# Altium schematic sheet pt_ctrl.SchDoc
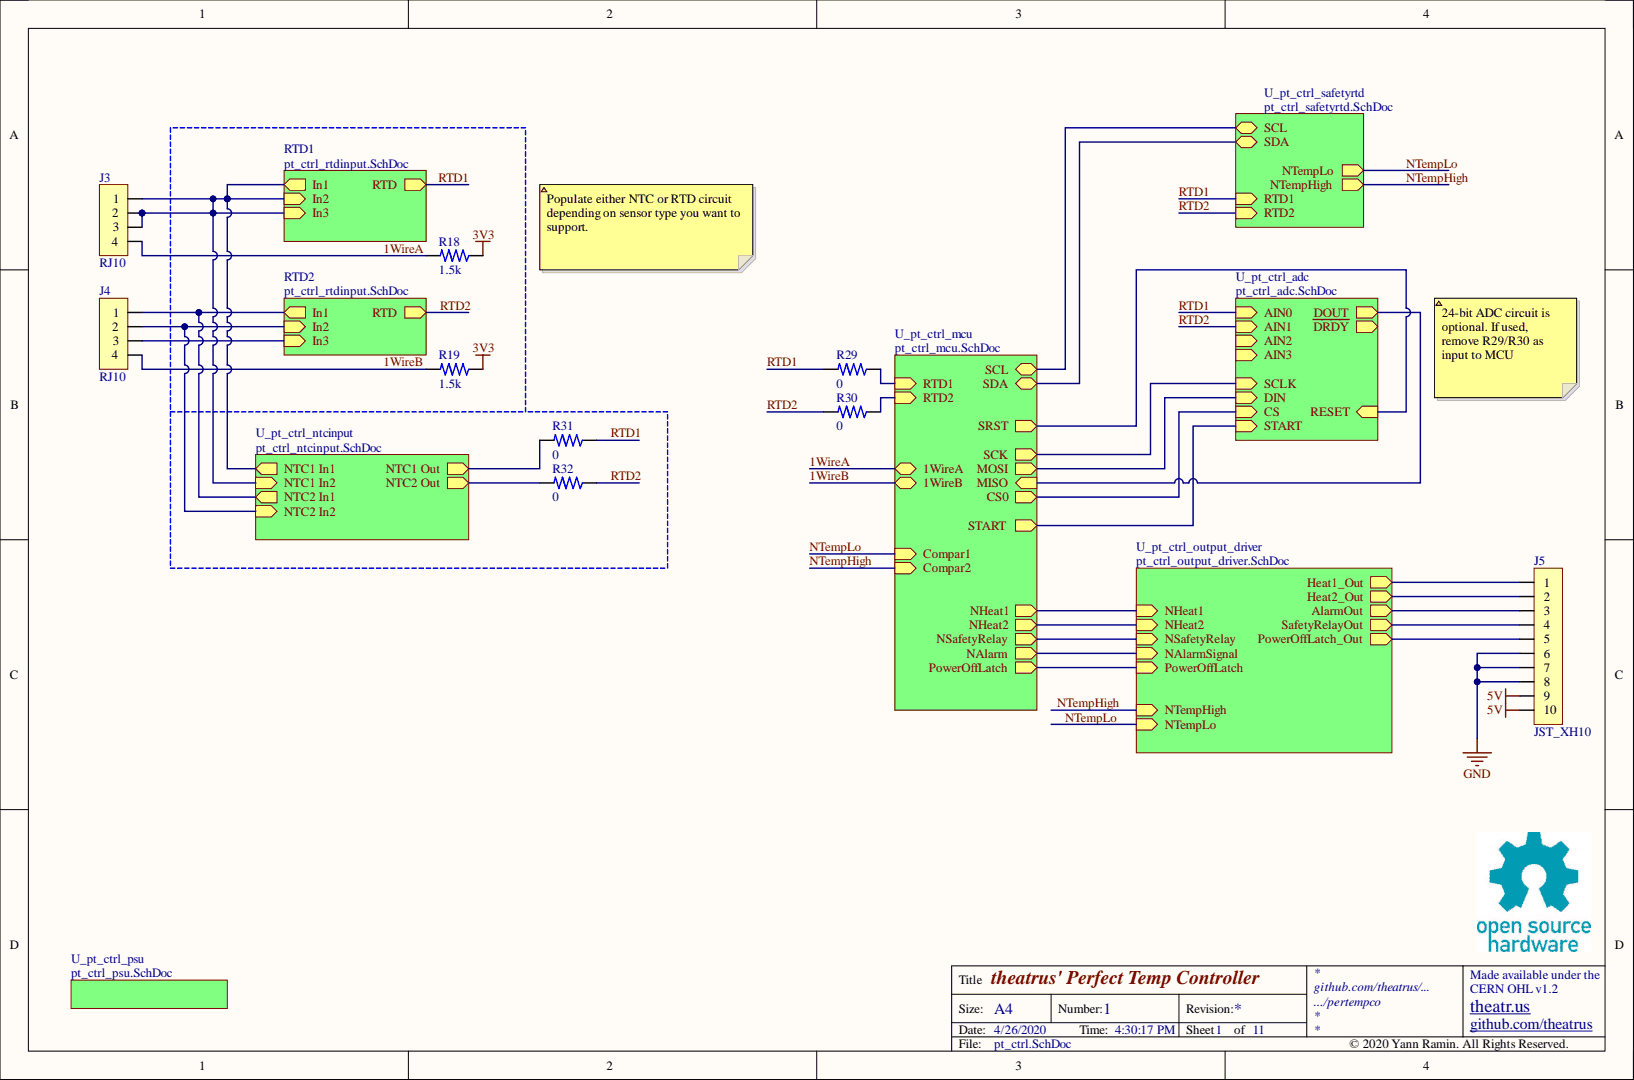
[diagram p0: pt_ctrl.SchDoc]

## Parts
J3  RJ10  [0424104170]
J4  RJ10  [0424104170]
J5  JST_XH10  [XH_10]
R18  1.5k  [R0603]
R19  1.5k  [R0603]
R29  0  [R0603]
R30  0  [R0603]
R31  0  [R0603]
R32  0  [R0603]

## Nets
3V3: R18.1 R19.1
5V: J5.9 J5.10
GND: J5.6 J5.7 J5.8
1WireA: J3.4 R18.2
1WireB: J4.4 R19.2
RTD1: R29.2 R31.1
RTD2: R30.2 R32.1
NetJ3_1: J3.1 J3.2 J3.3
NetJ4_1: J4.1
NetJ4_2: J4.2
NetJ4_3: J4.3
NetJ5_1: J5.1
NetJ5_2: J5.2
NetJ5_3: J5.3
NetJ5_4: J5.4
NetJ5_5: J5.5
NetR29_1: R29.1
NetR30_1: R30.1
NetR31_2: R31.2
NetR32_2: R32.2
AIN2:
AIN3:
CS:
~{DRDY}:
DIN:
DOUT:
NAlarm:
NHeat1:
NHeat2:
NSafetyRelay:
NTempHigh:
NTempLo:
PowerOffLatch:
RESET:
SCK:
SCL:
SDA:
START:

## Library data of the parts
R18 R19 R29-R32 = R-US: Component Kind: Standard; Library Name: rcl.IntLib
J3 J4 = RJ10: Component Kind: Standard; Library Name: connectors.IntLib
J5 = JST_XH10: Component Kind: Standard; Library Name: connectors.IntLib
